# Altium schematic sheet J19B_EM3B_PP0_POWER.SchDoc
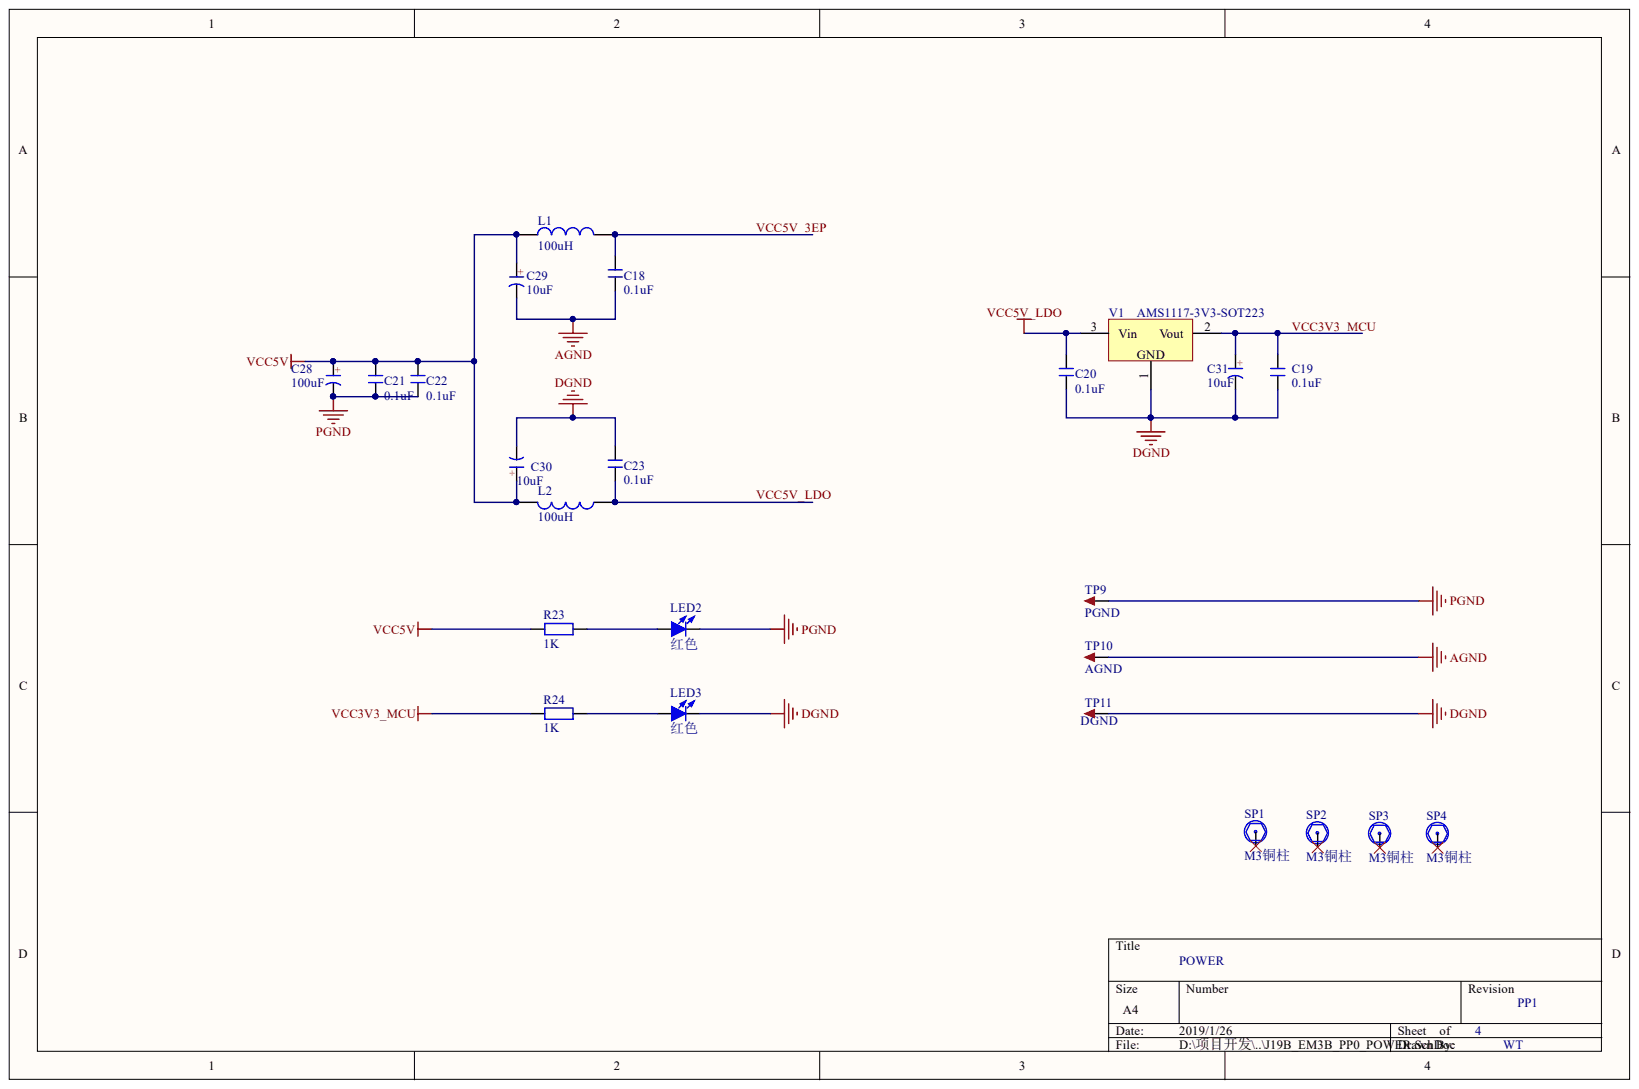
[diagram p0: J19B_EM3B_PP0_POWER.SchDoc]

## Parts
C18  0.1uF  [C 0603_L]
C19  0.1uF  [C 0603_L]
C20  0.1uF  [C 0603_L]
C21  0.1uF  [C 0603_L]
C22  0.1uF  [C 0603_L]
C23  0.1uF  [C 0603_L]
C28  100uF  [CD D(7343)]
C29  10uF  [CD A(3216)]
C30  10uF  [CD A(3216)]
C31  10uF  [CD A(3216)]
L1  100uH  [L 0805]
L2  100uH  [L 0805]
LED2  红色  [LED 0603R]
LED3  红色  [LED 0603R]
R23  1K  [R 0603_L]
R24  1K  [R 0603_L]
SP1  M3铜柱  [M3x20_L]
SP2  M3铜柱  [M3x20_L]
SP3  M3铜柱  [M3x20_L]
SP4  M3铜柱  [M3x20_L]
TP9  PGND  [TEST 2.0]
TP10  AGND  [TEST 2.0]
TP11  DGND  [TEST 2.0]
V1  AMS1117-3V3-SOT223  [SOT223_N]

## Nets
AGND: C18.2 C29.2 TP10.1
DGND: C19.1 C20.1 C23.2 C30.2 C31.2 LED3.2 TP11.1 V1.1
PGND: C21.1 C22.1 C28.2 LED2.2 TP9.1
VCC5V: C21.2 C22.2 C28.1 C29.1 C30.1 L1.1 L2.2 R23.1
VCC3V3_MCU: C19.2 C31.1 R24.1 V1.2
VCC5V_3EP: C18.1 L1.2
VCC5V_LDO: C20.2 C23.1 L2.1 V1.3
NetLED2_1: LED2.1 R23.2
NetLED3_1: LED3.1 R24.2

Not connected: SP1 1; SP2 1; SP3 1; SP4 1

## Library data of the parts
C18-C23 = C: 无极性贴片电容; 版权归属: 源创客; BOM名称: 无极性贴片电容; Component Kind: Standard; Library Name: 3.1   - 电阻-电容-电感.SchLib; 学习资源: www.ooocke.com
C28-C31 = C-CD: 贴片钽电容; 版权归属: 源创客; BOM名称: 贴片钽电容; Component Kind: Standard; Library Name: 3.1   - 电阻-电容-电感.SchLib; 学习资源: www.ooocke.com
L1 L2 = L: 小功率贴片电感; 版权归属: 源创客; BOM名称: 小功率贴片电感; Component Kind: Standard; Library Name: 3.1   - 电阻-电容-电感.SchLib; 学习资源: www.ooocke.com
LED2 LED3 = LED-SMD: 贴片LED; 版权归属: 源创客; BOM名称: 贴片LED; Component Kind: Standard; Library Name: 9.1   - LED.SchLib; 学习资源: www.ooocke.com
R23 R24 = R: 贴片电阻; 版权归属: 源创客; BOM名称: 贴片电阻; Component Kind: Standard; Library Name: 3.1   - 电阻-电容-电感.SchLib; 学习资源: www.ooocke.com
SP1-SP4 = 铜柱小焊盘: 铜柱; 版权归属: 源创客; BOM名称: 铜柱; Component Kind: Standard; Library Name: 15.1 - 未分类器件.SchLib; 学习资源: www.ooocke.com
TP9-TP11 = TEST: 测试点; 版权归属: 源创客; BOM名称: 测试点; Component Kind: Standard; Library Name: 15.1 - 未分类器件.SchLib; 学习资源: www.ooocke.com
V1 = AMS1117: 三端稳压芯片; BOM名称: 稳压芯片; 版权归属: 源创客; Component Kind: Standard; Library Name: 1.3   - 电源类芯片.SchLib; 学习资源: www.ooocke.com
